# Altium schematic sheet 10 - DEVICE POWER.SchDoc("10 - DEVICE POWER")
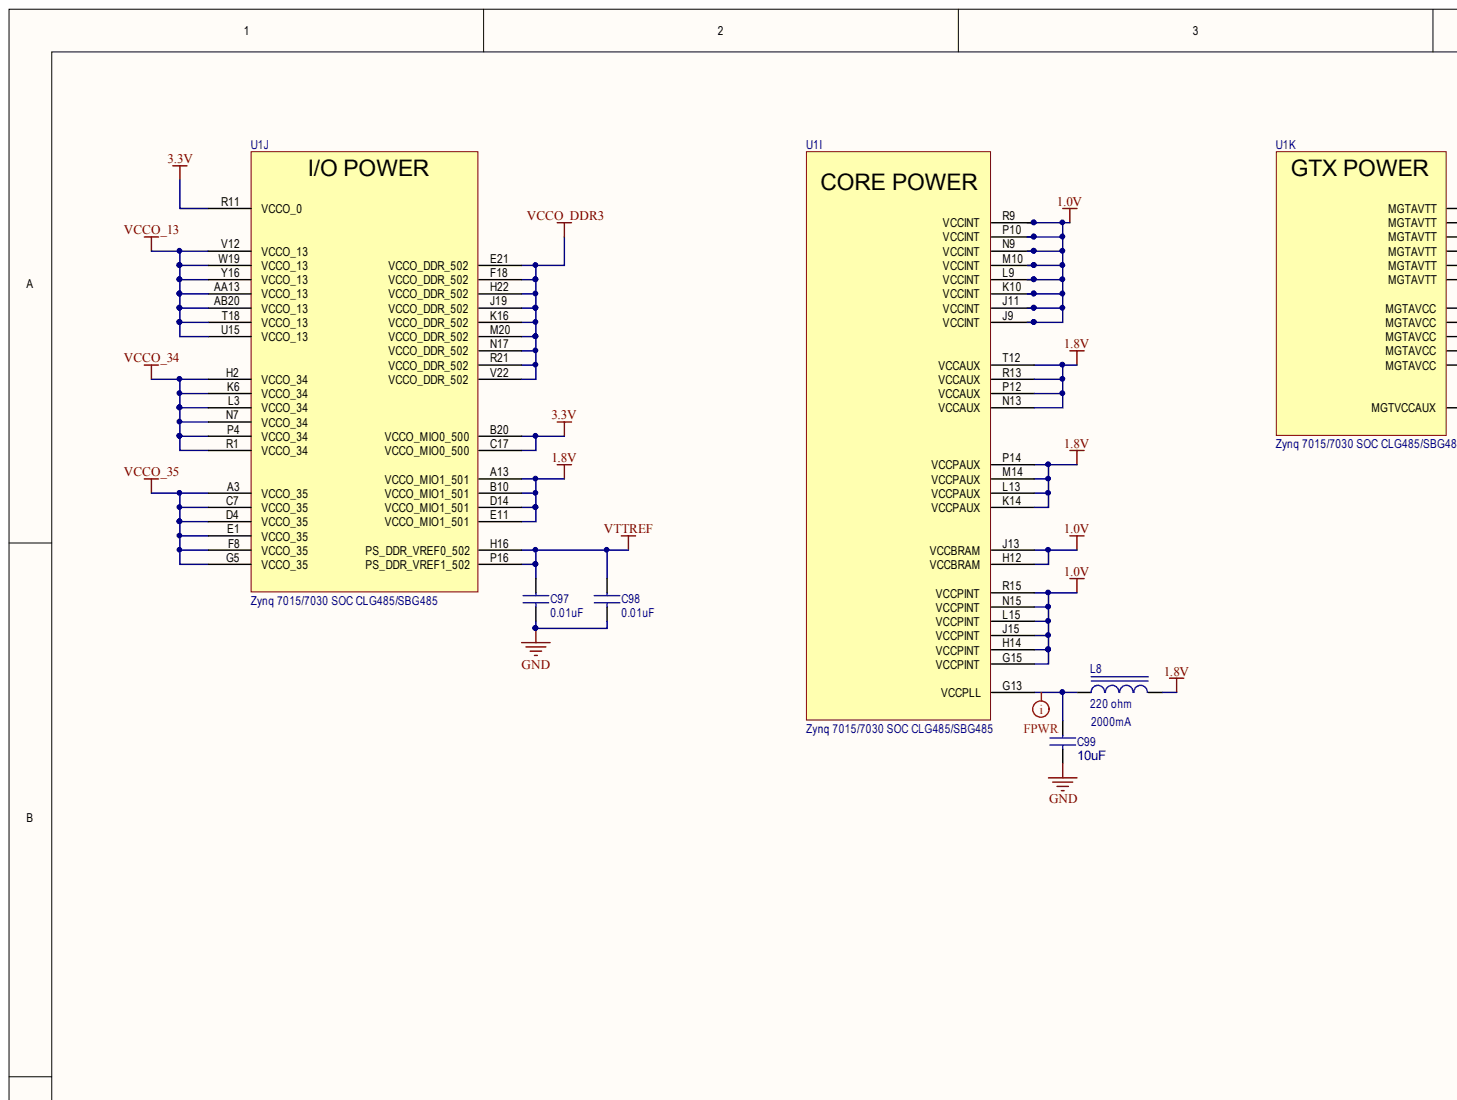
[diagram p0: 10 - DEVICE POWER.SchDoc, top left]
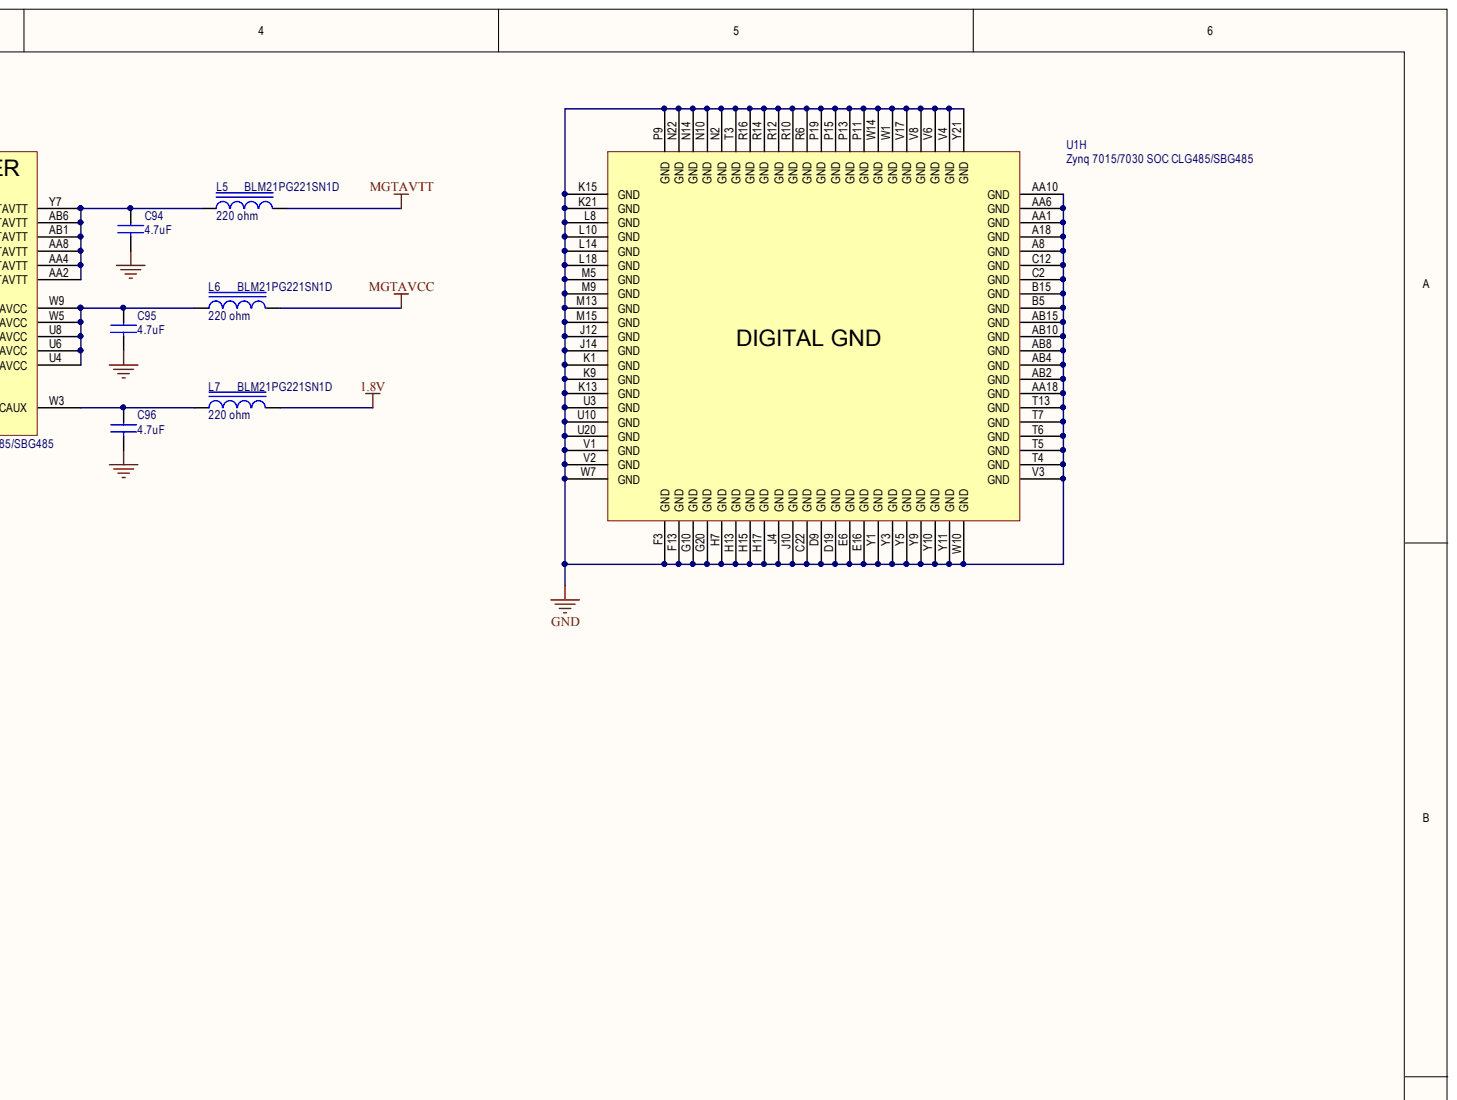
[diagram p1: 10 - DEVICE POWER.SchDoc, top right]
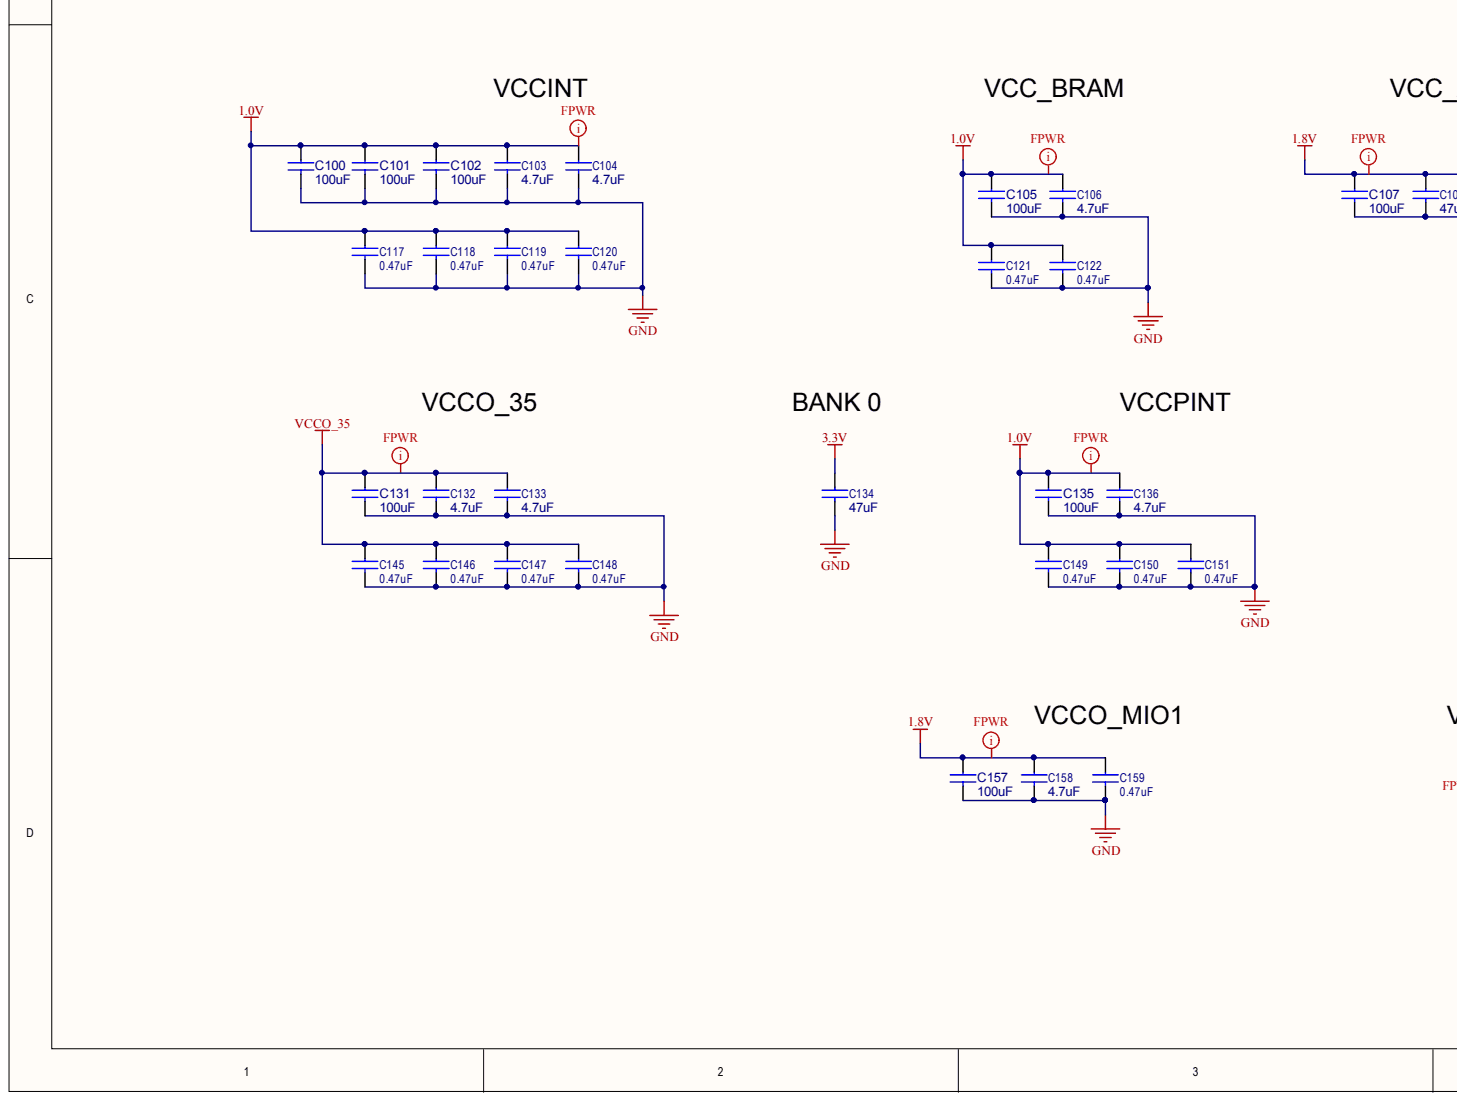
[diagram p2: 10 - DEVICE POWER.SchDoc, bottom left]
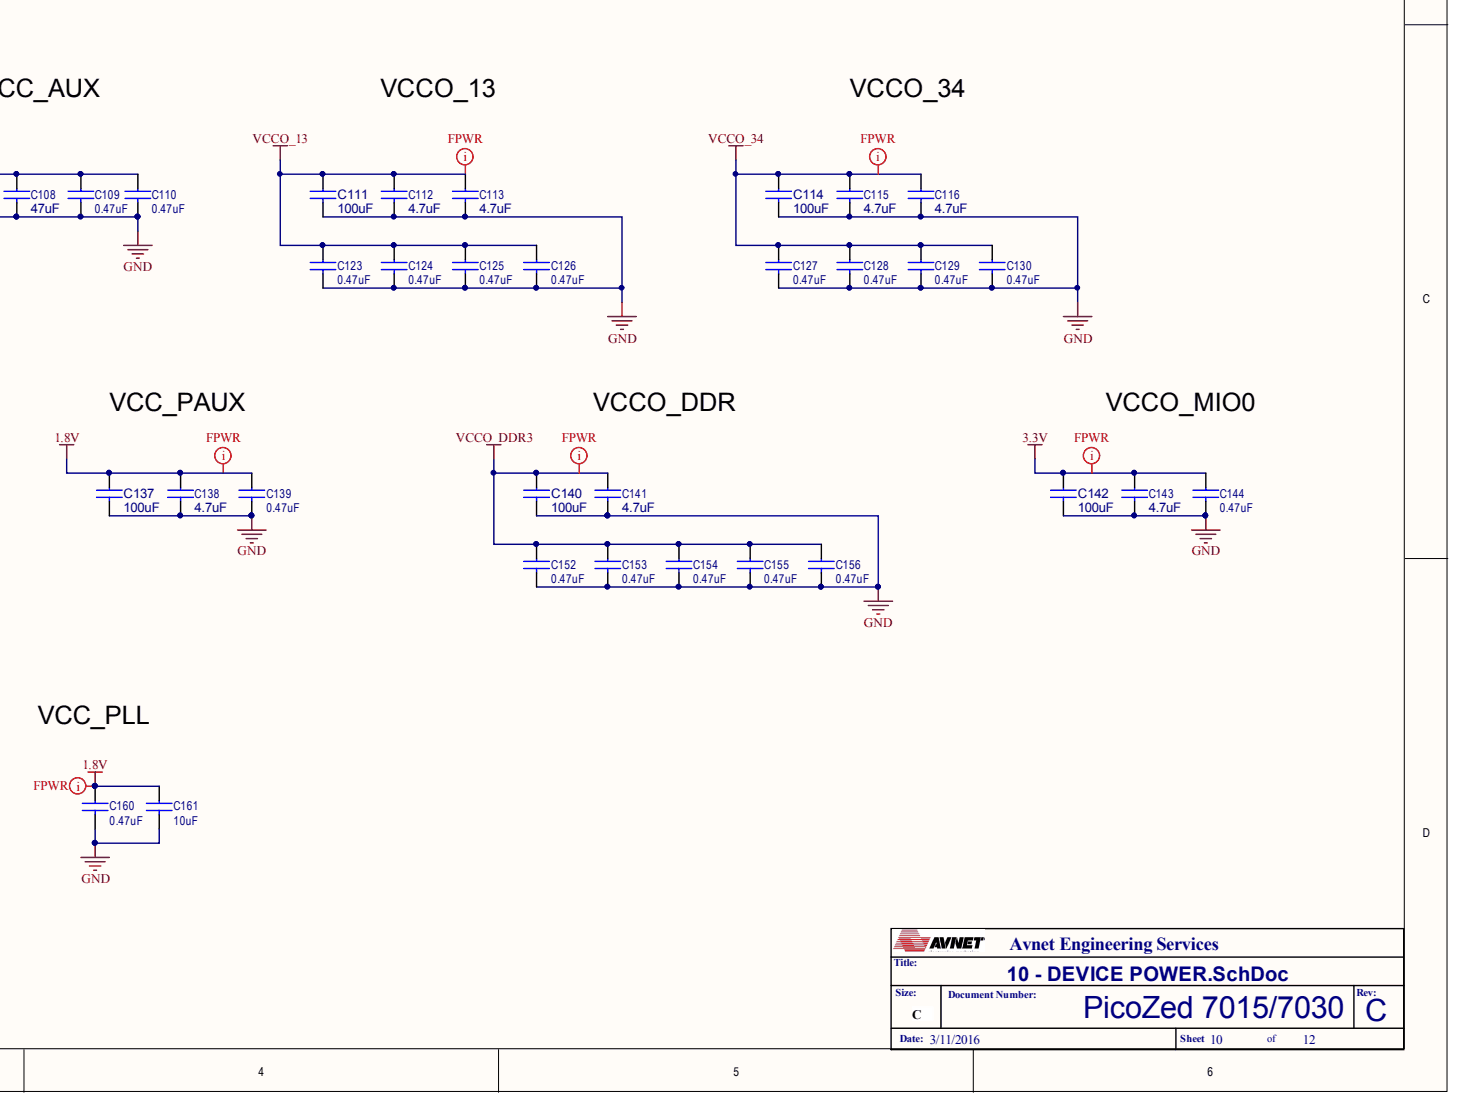
[diagram p3: 10 - DEVICE POWER.SchDoc, bottom right]

## Parts
C94  value 4.7uF  [C0402]  (hidden comment: 6.3V)
C95  value 4.7uF  [C0402]  (hidden comment: 6.3V)
C96  value 4.7uF  [C0402]  (hidden comment: 6.3V)
C97  value 0.01uF  [C0201]  (hidden comment: PC003)
C98  value 0.01uF  [C0201]  (hidden comment: PC003)
C99  10uF  [C0603]
C100  100uF  [C1210]
C101  100uF  [C1210]
C102  100uF  [C1210]
C103  4.7uF  [C0402]
C104  4.7uF  [C0402]
C105  100uF  [C1210]
C106  4.7uF  [C0402]
C107  100uF  [C1210]
C108  47uF  [C0805]
C109  value 0.47uF  [C0402]  (hidden comment: PC475)
C110  value 0.47uF  [C0402]  (hidden comment: PC475)
C111  100uF  [C1210]
C112  4.7uF  [C0402]
C113  4.7uF  [C0402]
C114  100uF  [C1210]
C115  4.7uF  [C0402]
C116  4.7uF  [C0402]
C117  value 0.47uF  [C0402]  (hidden comment: PC475)
C118  value 0.47uF  [C0402]  (hidden comment: PC475)
C119  value 0.47uF  [C0402]  (hidden comment: PC475)
C120  value 0.47uF  [C0402]  (hidden comment: PC475)
C121  value 0.47uF  [C0402]  (hidden comment: PC475)
C122  value 0.47uF  [C0402]  (hidden comment: PC475)
C123  value 0.47uF  [C0402]  (hidden comment: PC475)
C124  value 0.47uF  [C0402]  (hidden comment: PC475)
C125  value 0.47uF  [C0402]  (hidden comment: PC475)
C126  value 0.47uF  [C0402]  (hidden comment: PC475)
C127  value 0.47uF  [C0402]  (hidden comment: PC475)
C128  value 0.47uF  [C0402]  (hidden comment: PC475)
C129  value 0.47uF  [C0402]  (hidden comment: PC475)
C130  value 0.47uF  [C0402]  (hidden comment: PC475)
C131  100uF  [C1210]
C132  4.7uF  [C0402]
C133  4.7uF  [C0402]
C134  47uF  [C0805]
C135  100uF  [C1210]
C136  4.7uF  [C0402]
C137  100uF  [C1210]
C138  4.7uF  [C0402]
C139  value 0.47uF  [C0402]  (hidden comment: PC475)
C140  100uF  [C1210]
C141  4.7uF  [C0402]
C142  100uF  [C1210]
C143  4.7uF  [C0402]
C144  value 0.47uF  [C0402]  (hidden comment: PC475)
C145  value 0.47uF  [C0402]  (hidden comment: PC475)
C146  value 0.47uF  [C0402]  (hidden comment: PC475)
C147  value 0.47uF  [C0402]  (hidden comment: PC475)
C148  value 0.47uF  [C0402]  (hidden comment: PC475)
C149  value 0.47uF  [C0402]  (hidden comment: PC475)
C150  value 0.47uF  [C0402]  (hidden comment: PC475)
C151  value 0.47uF  [C0402]  (hidden comment: PC475)
C152  value 0.47uF  [C0402]  (hidden comment: PC475)
C153  value 0.47uF  [C0402]  (hidden comment: PC475)
C154  value 0.47uF  [C0402]  (hidden comment: PC475)
C155  value 0.47uF  [C0402]  (hidden comment: PC475)
C156  value 0.47uF  [C0402]  (hidden comment: PC475)
C157  100uF  [C1210]
C158  4.7uF  [C0402]
C159  value 0.47uF  [C0402]  (hidden comment: PC475)
C160  value 0.47uF  [C0402]  (hidden comment: PC475)
C161  value 10uF  [C1206]  (hidden comment: PC219)
L5  BLM21PG221SN1D  value 220 ohm  [L0805]
L6  BLM21PG221SN1D  value 220 ohm  [L0805]
L7  BLM21PG221SN1D  value 220 ohm  [L0805]
L8  value 220 ohm  [L0805]  (hidden comment: PF009)
U1  Zynq 7015/7030 SOC CLG485/SBG485  [BGA81C80P22X22_1900X1900X244]  (parts H,I,J,K)

## Nets
1.0V: C100.1 C101.1 C102.1 C103.1 C104.1 C105.1 C106.1 C117.1 C118.1 C119.1 C120.1 C121.1 C122.1 C135.1 C136.1 C149.1 C150.1 C151.1 U1.G15(VCCPINT) U1.H12(VCCBRAM) U1.H14(VCCPINT) U1.J9(VCCINT) U1.J11(VCCINT) U1.J13(VCCBRAM) U1.J15(VCCPINT) U1.K10(VCCINT) U1.L9(VCCINT) U1.L15(VCCPINT) U1.M10(VCCINT) U1.N9(VCCINT) U1.N15(VCCPINT) U1.P10(VCCINT) U1.R9(VCCINT) U1.R15(VCCPINT)
1.8V: C107.1 C108.1 C109.1 C110.1 C137.1 C138.1 C139.1 C157.1 C158.1 C159.1 C160.1 C161.1 L7.2 L8.2 U1.A13(VCCO_MIO1_501) U1.B10(VCCO_MIO1_501) U1.D14(VCCO_MIO1_501) U1.E11(VCCO_MIO1_501) U1.K14(VCCPAUX) U1.L13(VCCPAUX) U1.M14(VCCPAUX) U1.N13(VCCAUX) U1.P12(VCCAUX) U1.P14(VCCPAUX) U1.R13(VCCAUX) U1.T12(VCCAUX)
3.3V: C134.1 C142.1 C143.1 C144.1 U1.B20(VCCO_MIO0_500) U1.C17(VCCO_MIO0_500) U1.R11(VCCO_0)
GND: C94.2 C95.2 C96.2 C97.2 C98.2 C99.2 C100.2 C101.2 C102.2 C103.2 C104.2 C105.2 C106.2 C107.2 C108.2 C109.2 C110.2 C111.2 C112.2 C113.2 C114.2 C115.2 C116.2 C117.2 C118.2 C119.2 C120.2 C121.2 C122.2 C123.2 C124.2 C125.2 C126.2 C127.2 C128.2 C129.2 C130.2 C131.2 C132.2 C133.2 C134.2 C135.2 C136.2 C137.2 C138.2 C139.2 C140.2 C141.2 C142.2 C143.2 C144.2 C145.2 C146.2 C147.2 C148.2 C149.2 C150.2 C151.2 C152.2 C153.2 C154.2 C155.2 C156.2 C157.2 C158.2 C159.2 C160.2 C161.2 U1.A8(GND) U1.A18(GND) U1.AA1(GND) U1.AA6(GND) U1.AA10(GND) U1.AA18(GND) U1.AB2(GND) U1.AB4(GND) U1.AB8(GND) U1.AB10(GND) U1.AB15(GND) U1.B5(GND) U1.B15(GND) U1.C2(GND) U1.C12(GND) U1.C22(GND) U1.D9(GND) U1.D19(GND) U1.E6(GND) U1.E16(GND) U1.F3(GND) U1.F13(GND) U1.G10(GND) U1.G20(GND) U1.H7(GND) U1.H13(GND) U1.H15(GND) U1.H17(GND) U1.J4(GND) U1.J10(GND) U1.J12(GND) U1.J14(GND) U1.K1(GND) U1.K9(GND) U1.K13(GND) U1.K15(GND) U1.K21(GND) U1.L8(GND) U1.L10(GND) U1.L14(GND) U1.L18(GND) U1.M5(GND) U1.M9(GND) U1.M13(GND) U1.M15(GND) U1.N2(GND) U1.N10(GND) U1.N14(GND) U1.N22(GND) U1.P9(GND) U1.P11(GND) U1.P13(GND) U1.P15(GND) U1.P19(GND) U1.R6(GND) U1.R10(GND) U1.R12(GND) U1.R14(GND) U1.R16(GND) U1.T3(GND) U1.T4(GND) U1.T5(GND) U1.T6(GND) U1.T7(GND) U1.T13(GND) U1.U3(GND) U1.U10(GND) U1.U20(GND) U1.V1(GND) U1.V2(GND) U1.V3(GND) U1.V4(GND) U1.V6(GND) U1.V8(GND) U1.V17(GND) U1.W1(GND) U1.W7(GND) U1.W10(GND) U1.W14(GND) U1.Y1(GND) U1.Y3(GND) U1.Y5(GND) U1.Y9(GND) U1.Y10(GND) U1.Y11(GND) U1.Y21(GND)
MGTAVCC: L6.2
MGTAVTT: L5.2
VCCO_13: C111.1 C112.1 C113.1 C123.1 C124.1 C125.1 C126.1 U1.AA13(VCCO_13) U1.AB20(VCCO_13) U1.T18(VCCO_13) U1.U15(VCCO_13) U1.V12(VCCO_13) U1.W19(VCCO_13) U1.Y16(VCCO_13)
VCCO_34: C114.1 C115.1 C116.1 C127.1 C128.1 C129.1 C130.1 U1.H2(VCCO_34) U1.K6(VCCO_34) U1.L3(VCCO_34) U1.N7(VCCO_34) U1.P4(VCCO_34) U1.R1(VCCO_34)
VCCO_35: C131.1 C132.1 C133.1 C145.1 C146.1 C147.1 C148.1 U1.A3(VCCO_35) U1.C7(VCCO_35) U1.D4(VCCO_35) U1.E1(VCCO_35) U1.F8(VCCO_35) U1.G5(VCCO_35)
VCCO_DDR3: C140.1 C141.1 C152.1 C153.1 C154.1 C155.1 C156.1 U1.E21(VCCO_DDR_502) U1.F18(VCCO_DDR_502) U1.H22(VCCO_DDR_502) U1.J19(VCCO_DDR_502) U1.K16(VCCO_DDR_502) U1.M20(VCCO_DDR_502) U1.N17(VCCO_DDR_502) U1.R21(VCCO_DDR_502) U1.V22(VCCO_DDR_502)
VTTREF: C97.1 C98.1 U1.H16(PS_DDR_VREF0_502) U1.P16(PS_DDR_VREF1_502)
NetC94_1: C94.1 L5.1 U1.AA2(MGTAVTT) U1.AA4(MGTAVTT) U1.AA8(MGTAVTT) U1.AB1(MGTAVTT) U1.AB6(MGTAVTT) U1.Y7(MGTAVTT)
NetC95_1: C95.1 L6.1 U1.U4(MGTAVCC) U1.U6(MGTAVCC) U1.U8(MGTAVCC) U1.W5(MGTAVCC) U1.W9(MGTAVCC)
NetC96_1: C96.1 L7.1 U1.W3(MGTVCCAUX)
NetC99_1: C99.1 L8.1 U1.G13(VCCPLL)

## Library data of the parts
C94-C96 = AVPC-0000499: CAP SM 4.7uF 6.3V 20% X5R C0402; MFG: Murata; MFG Code: AVX; MFGPN: GRM155R60J475ME87D; Temp: I; Component Kind: Standard; Library Name: ZYNQ_Z7045_MITX_REVA.SCHLIB
C97 C98 = AVPC-0000003: CAP SM 0.01uF 10V 10% X5R C0201; PartCode: PC; Database Table Name: Passive-Cap$; MFG: AVX; MFGPN: 0201ZD103KAT2A; Temp: I; AsyTxt: PC003; AVLNo: AVPC-0000003; Component Kind: Standard; ComponentLink1Description: Datasheet; ComponentLink2Description: MFR Website; ComponentLink2URL: www.avx.com; Device: CAP; Dielectric: X5R; ESR: CAP; PkgType: SM; RoHS: Yes; Status: Preliminary; Tol: 10%; Volt: 10V; ComponentLink1URL: C:\AVDBLIB\DATASHEETS\AVX_CX5R.pdf; Library Name: Avnet_DBlib.DbLib
C99 = AVPC-0000086: CAP SM 10uF 6.3V 20% X5R C0603; PartCode: PC; AsyTxt: PC086; Database Table Name: Passive-Cap$; MFG: AVX; MFGPN: 06036D106MAT2A; Temp: I; AVLNo: AVPC-0000086; CLASSNAME: U1_CAPS; Component Kind: Standard; ComponentLink1Description: Datasheet; Device: CAP; Dielectric: X5R; ESR: CAP; MFG Code: AVX; PkgType: SM; RoHS: Yes; Status: Preliminary; Tol: 20%; Value: 10uF; Volt: 6.3V; ComponentLink1URL: C:\AVDBLIB\DATASHEETS\AVX_CCOG.pdf; Library Name: Avnet_DBlib.DbLib
C100-C102 C105 C107 C111 C114 C131 C135 C137 C140 C142 C157 = AVPC-0000209: CAP SM 100uF 6.3V 20% X5R C1206; PartCode: PC; AsyTxt: PC209; Database Table Name: Passive-Cap$; MFG: Murata; MFG Code: MUR; MFGPN: GRM32EF50J107ZE20K; Power: 0; Temp: I; Availability: 180K-AV; AVLNo: AVPC-0000209; CLASSNAME: DDR_TERM; Component Kind: Standard; ComponentLink1Description: Datasheet; Cost: .41; Device: CAP; Dielectric: X5R; PkgType: SM; Received: 0; RoHS: Yes; Size: 9.11; Status: Preliminary; Tol: 20%; Value: 100uF; Volt: 6.3V; ComponentLink1URL: C:\AVDBLIB\DATASHEETS\GRM31CR60J107ME39.pdf; Library Name: Avnet_DBlib.DbLib
C103 C104 C106 C112 C113 C115 C116 C132 C133 C136 C138 C141 C158 = AVPC-0000499: CAP SM 4.7uF 6.3V 20% X5R C0402; PartCode: PC; AsyTxt: PC499; Database Table Name: Passive-Cap$; MFG: Murata; MFG Code: AVX; MFGPN: GRM155R60J475ME87D; Power: 0; Temp: I; Availability: 140K-DK; AVLNo: AVPC-0000499; CLASSNAME: U1_CAPS; Component Kind: Standard; ComponentLink1Description: Datasheet; Cost: .46; Device: CAP; Dielectric: X5R; PkgType: SM; Received: 0; RoHS: Yes; Size: 1.9; Status: Preliminary; Tol: 20%; Value: 4.7uF; Volt: 6.3V; ComponentLink1URL: C:\AVDBLIB\DATASHEETS\murata_caps_c02e.pdf; Library Name: Avnet_DBlib.DbLib
C108 C134 = AVPC-0000521: CAP SM 47uF 6.3V 20% X5R C0805; PartCode: PC; AsyTxt: PC521; Database Table Name: Passive-Cap$; MFG: Taiyo Yuden; MFG Code: TYC; MFGPN: JMK212BJ476MG-T; Power: 0; Temp: I; Availability: 100K-AV; AVLNo: AVPC-0000521; CLASSNAME: U1_CAPS; Component Kind: Standard; ComponentLink1Description: Datasheet; Cost: .18; Device: CAP; Dielectric: X5R; PkgType: SM; Received: 0; RoHS: Yes; Size: 5.42; Status: Preliminary; Tol: 20%; Value: 47uF; Volt: 6.3V; ComponentLink1URL: C:\AVDBLIB\DATASHEETS\TYC_Caps.pdf; Library Name: Avnet_DBlib.DbLib
C109 C110 C118-C130 C139 C144-C156 C159 C160 = AVPC-0000475: CAP SM 0.47uF 6.3V 10% X5R C0402; PartCode: PC; AVLNo: AVPC-0000529; Database Table Name: Passive-Cap$; MFG: Taiyo Yuden; MFG Code: TAI; MFGPN: JMK105BJ474KV-F; Temp: I; AsyTxt: PC475; CLASSNAME: U1_CAPS; Component Kind: Standard; ComponentLink1Description: Datasheet; ComponentLink2Description: MFR Website; Device: CAP; Dielectric: X5R; ESR: CAP; PkgType: SM; RoHS: Yes; Status: Preliminary; Tol: 10%; Volt: 6.3V; ComponentLink1URL: C:\AVDBLIB\DATASHEETS\; Library Name: Avnet_DBlib.DbLib
C117 = AVPC-0000475: CAP SM 0.47uF 6.3V 10% X5R C0402; PartCode: PC; AVLNo: AVPC-0000529; CLASSNAMECLASSNAME: U1_CAPS; Database Table Name: Passive-Cap$; MFG: Taiyo Yuden; MFG Code: TAI; MFGPN: JMK105BJ474KV-F; Temp: I; AsyTxt: PC475; CLASSNAME: U1_CAPS; Component Kind: Standard; ComponentLink1Description: Datasheet; ComponentLink2Description: MFR Website; Device: CAP; Dielectric: X5R; ESR: CAP; PkgType: SM; RoHS: Yes; Status: Preliminary; Tol: 10%; Volt: 6.3V; ComponentLink1URL: C:\AVDBLIB\DATASHEETS\; Library Name: Avnet_DBlib.DbLib
C143 = AVPC-0000499: CAP SM 4.7uF 6.3V 20% X5R C0402; PartCode: PC; AsyTxt: PC499; CLASSNAMECLASSNAMECLASSNAME: U1_CAPS; Database Table Name: Passive-Cap$; MFG: Murata; MFG Code: AVX; MFGPN: GRM155R60J475ME87D; Power: 0; Temp: I; Availability: 140K-DK; AVLNo: AVPC-0000499; CLASSNAME: U1_CAPS; Component Kind: Standard; ComponentLink1Description: Datasheet; Cost: .46; Device: CAP; Dielectric: X5R; PkgType: SM; Received: 0; RoHS: Yes; Size: 1.9; Status: Preliminary; Tol: 20%; Value: 4.7uF; Volt: 6.3V; ComponentLink1URL: C:\AVDBLIB\DATASHEETS\murata_caps_c02e.pdf; Library Name: Avnet_DBlib.DbLib
C161 = AVPC-0000219: CAP SM 10uF 25V 10% X5R C1206; PartCode: PC; Database Table Name: Passive-Cap$; MFG: AVX; MFGPN: 12063D106KAT2A; Temp: I; AsyTxt: PC219; AVLNo: AVPC-0000219; Component Kind: Standard; ComponentLink1Description: Datasheet; ComponentLink2Description: MFR Website; ComponentLink2URL: www.avx.com; Device: CAP; Dielectric: X5R; ESR: CAP; MFG Code: AVX; PkgType: SM; RoHS: Yes; Status: Preliminary; Tol: 10%; Volt: 25V; ComponentLink1URL: C:\AVDBLIB\DATASHEETS\AVX_CX5R.pdf; Library Name: Avnet_DBlib.DbLib
L5-L7 = AVPF-0000009: FB SM 220 ohm@100MHz 2000mA 0.25 0.045 ohm@DC L0805; MFG: Murata; MFG Code: MUR; Temp: C; Component Kind: Standard; MFGPN: BLM21PG221SN1D; Library Name: ZYNQ_Z7045_MITX_REVA.SCHLIB
L8 = AVPF-0000009: FB SM 220 ohm@100MHz 2000mA 0.25 0.045 ohm@DC L0805; PartCode: PF; Database Table Name: Passive-Ferrite Bead$; MFG: Murata; MFG Code: MUR; MFGPN: BLM21PG221SN1D; Temp: C; Test Freq: 100MHz; Tol: 0.25; AsyTxt: PF009; AVLNo: AVPF-0000009; CLASSNAME: U1_CAPS; Component Kind: Standard; ComponentLink1Description: Datasheet; DCR: 0.045 ohm; Device: FB; Max I: 2000mA; PkgType: SM; RoHS: Yes; Status: Preliminary; ComponentLink1URL: C:\AVDBLIB\DATASHEETS\L0110S0100BLM21P.pdf; Library Name: Avnet_DBlib.DbLib
U1 = Zynq 7015/7030 SOC SBG485: MFG: Xilinx; MFGPN: XC7Z030-1SBG485-C; Temp: C; CLASSNAME: BGA; Component Kind: Standard; Description: Zynq 7015/7030 SOC SBG485; Library Name: PicoZed+_Rev_A.SCHLIB
